# Altium schematic sheet MIXR Power - Battery Management System.SchDoc
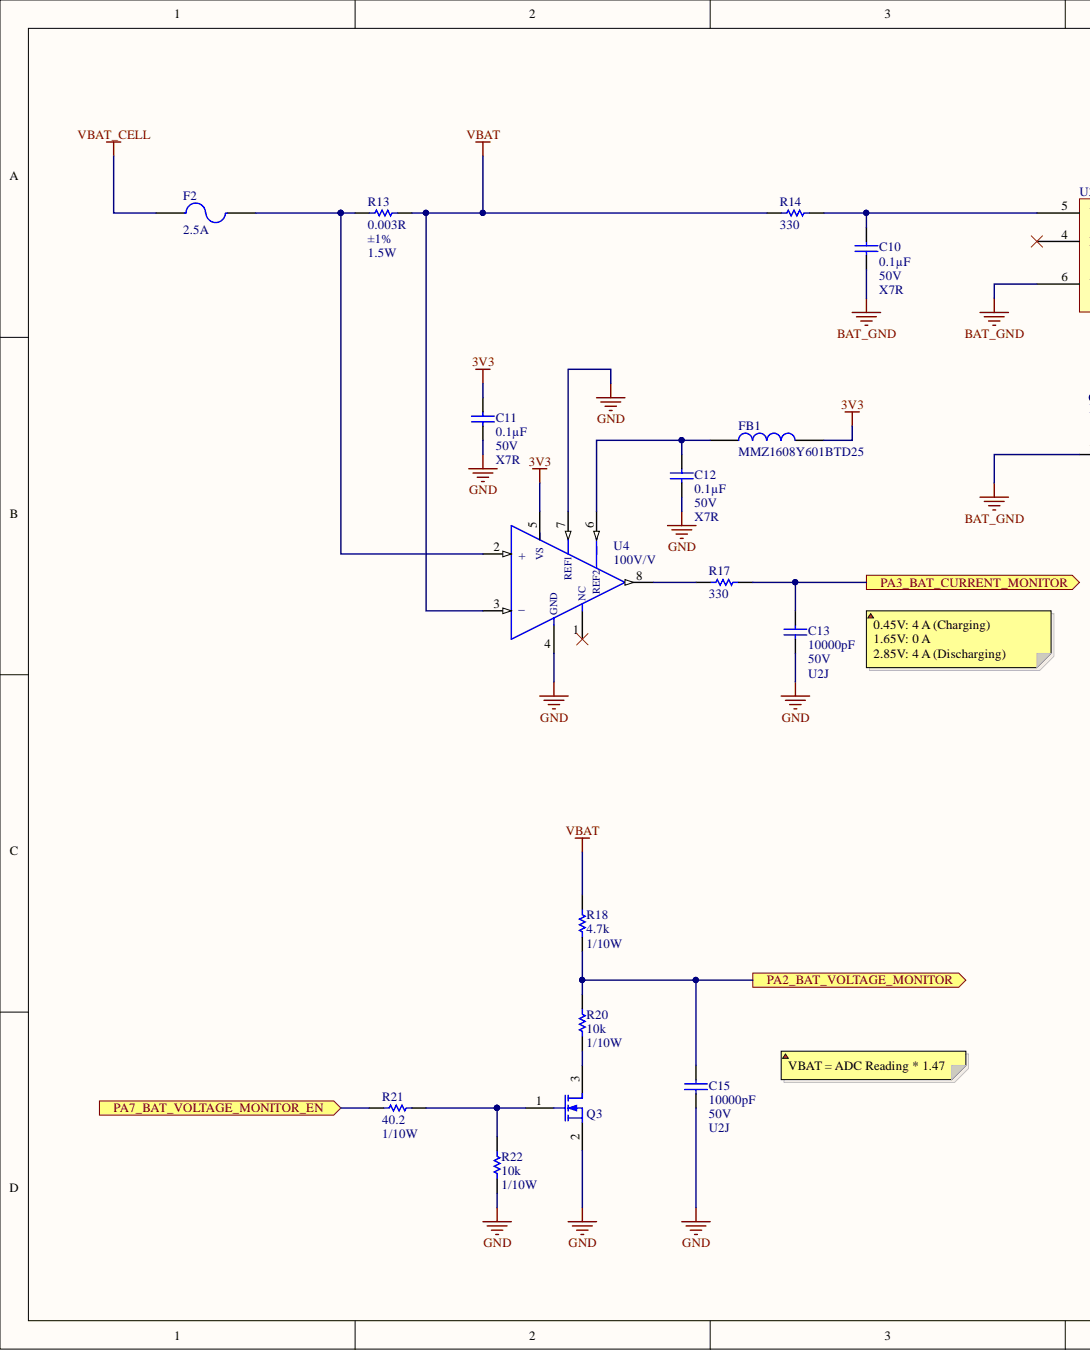
[diagram p0: MIXR Power - Battery Management System.SchDoc, left]
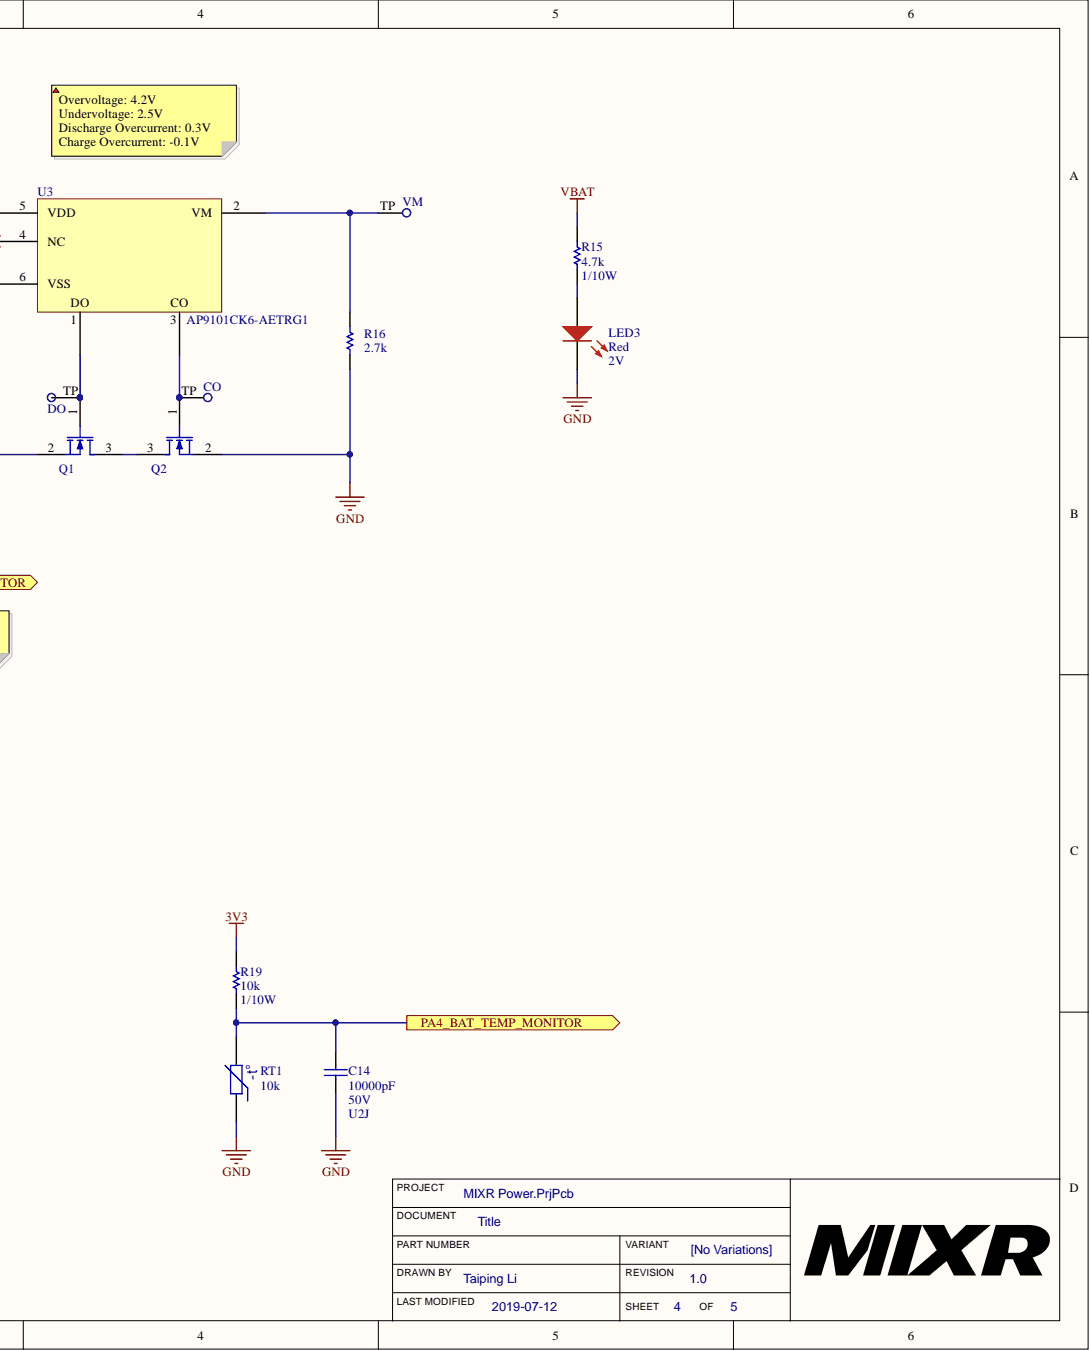
[diagram p1: MIXR Power - Battery Management System.SchDoc, right]

## Parts
C10  Capacitance: 0.1µF  Temperature Coefficient: X7R  Voltage: 50V  [CAP, 0603]  (hidden comment: CAP CER 0.1UF 50V 10% X7R 0603)
C11  Capacitance: 0.1µF  Temperature Coefficient: X7R  Voltage: 50V  [CAP, 0603]  (hidden comment: CAP CER 0.1UF 50V 10% X7R 0603)
C12  Capacitance: 0.1µF  Temperature Coefficient: X7R  Voltage: 50V  [CAP, 0603]  (hidden comment: CAP CER 0.1UF 50V 10% X7R 0603)
C13  Capacitance: 10000pF  Temperature Coefficient: U2J  Voltage: 50V  [CAP, 0603]  (hidden comment: CAP CER 10nF 50V 5% X7R 0603)
C14  Capacitance: 10000pF  Temperature Coefficient: U2J  Voltage: 50V  [CAP, 0603]  (hidden comment: CAP CER 10nF 50V 5% X7R 0603)
C15  Capacitance: 10000pF  Temperature Coefficient: U2J  Voltage: 50V  [CAP, 0603]  (hidden comment: CAP CER 10nF 50V 5% X7R 0603)
CO  Test Point  [Test Point]  (value not drawn)  (no BOM)
DO  Test Point  [Test Point]  (value not drawn)  (no BOM)
F2  Current Rating: 2.5A  [FUSE LITTLEFUSE 0466 1206]  (hidden comment: FUSE 2.5A 32VDC 1206)
FB1  Manufacturer Part Number: MMZ1608Y601BTD25  [FB 0603]  (hidden comment: FB 600 OHM 1LN 0603)
LED3  Color: Red  Voltage - Forward (Vf) (Typ): 2V  [LED_0603]  (hidden comment: LED RED CLEAR 2V 0603)
Q1  MOSFET N-CH 30V 6.2A 0.9W SOT-23  [MOSFET N-CH 30V 6.2A 0.9W SOT-23]  (value not drawn)
Q2  MOSFET N-CH 30V 6.2A 0.9W SOT-23  [MOSFET N-CH 30V 6.2A 0.9W SOT-23]  (value not drawn)
Q3  MOSFET N-CH 30V 6.2A 0.9W SOT-23  [MOSFET N-CH 30V 6.2A 0.9W SOT-23]  (value not drawn)
R13  Power (Watts): 1.5W  Resistance (Ohms): 0.003R  Tolerance: ±1%  [RES 2010]  (hidden comment: RES 0.003 OHM 1% 1.5W 2010)
R14  value 330  [RES, 0603]  (hidden comment: RES 330 OHM 1% 1/10W 0603)
R15  Power (Watts): 1/10W  Resistance (Ohms): 4.7k  [RES, 0603]  (hidden comment: RES 4.7K OHM 1% 1/10W 0603)
R16  2.7k  [RES, 0603]
R17  value 330  [RES, 0603]  (hidden comment: RES 330 OHM 1% 1/10W 0603)
R18  Power (Watts): 1/10W  Resistance (Ohms): 4.7k  [RES, 0603]  (hidden comment: RES 4.7K OHM 1% 1/10W 0603)
R19  Power: 1/10W  Resistance: 10k  [RES, 0603]  (hidden comment: RES 10K OHM 1% 1/10W 0603)
R20  Power: 1/10W  Resistance: 10k  [RES, 0603]  (hidden comment: RES 10K OHM 1% 1/10W 0603)
R21  Power: 1/10W  Resistance: 40.2  [RES, 0603]  (hidden comment: RES 40.2 OHM 0.5% 1/10W 0603)
R22  Power: 1/10W  Resistance: 10k  [RES, 0603]  (hidden comment: RES 10K OHM 1% 1/10W 0603)
RT1  Resistance in Ohms @ 25°C: 10k  [RES, 0603]  (hidden comment: NTC THERMISTORS 10K 0603 SMD)
U3  Manufacturer Part Number: AP9101CK6-AETRG1  [SOT23-6]  (hidden comment: IC BATT PROT LI-ION 1-CELL SOT26)
U4  100V/V  [IC CURRENT AMPLIFIER INA240 TSSOP-8]
VM  Test Point  [Test Point]  (value not drawn)  (no BOM)

## Ports
PA2_BAT_VOLTAGE_MONITOR, PA3_BAT_CURRENT_MONITOR, PA4_BAT_TEMP_MONITOR, PA7_BAT_VOLTAGE_MONITOR_EN

## Nets
3V3: C11.1 FB1.2 R19.1 U4.5(VS)
BAT_GND: C10.2 Q1.2 U3.6(VSS)
GND: C11.2 C12.2 C13.2 C14.2 C15.2 LED3.2 Q2.2 Q3.2 R16.2 R22.2 RT1.1 U4.4(GND) U4.7(REF1)
VBAT: R13.2 R14.1 R15.1 R18.1 U4.3(_)
VBAT_CELL: F2.1
PA2_BAT_VOLTAGE_MONITOR: C15.1 R18.2 R20.1 ; port PA2_BAT_VOLTAGE_MONITOR
PA3_BAT_CURRENT_MONITOR: C13.1 R17.2 ; port PA3_BAT_CURRENT_MONITOR
PA4_BAT_TEMP_MONITOR: C14.1 R19.2 RT1.2 ; port PA4_BAT_TEMP_MONITOR
PA7_BAT_VOLTAGE_MONITOR_EN: R21.1 ; port PA7_BAT_VOLTAGE_MONITOR_EN
NetC10_1: C10.1 R14.2 U3.5(VDD)
NetC12_1: C12.1 FB1.1 U4.6
NetCO_TP: CO.TP Q2.1 U3.3(CO)
NetDO_TP: DO.TP Q1.1 U3.1(DO)
NetF2_2: F2.2 R13.1 U4.2(+)
NetLED3_1: LED3.1 R15.2
NetQ1_3: Q1.3 Q2.3
NetQ3_1: Q3.1 R21.2 R22.1
NetQ3_3: Q3.3 R20.2
NetR16_1: R16.1 U3.2(VM) VM.TP
NetR17_1: R17.1 U4.8

Not connected: U3 4(NC); U4 1(NC)

## Library data of the parts
C10-C12 = CAP CER 0.1UF 50V 10% X7R 0603: 0.10µF ±10% 50V Ceramic Capacitor X7R 0603 (1608 Metric); Manufacturer 1: AVX Corporation; Manufacturer Part Number 1: 06035C104KAT2A; Component Kind: Standard; ComponentLink1Description: http://datasheets.avx.com/X7RDielectric.pdf; ComponentLink1URL: http://datasheets.avx.com/X7RDielectric.pdf; Height - Seated (Max): -; Lead Spacing: -; Lead Style: -; Mounting Type: Surface Mount, MLCC; Operating Temperature: -55°C ~ 125°C; Package / Case: 0603 (1608 Metric); Packaging: Cut Tape (CT); Part Status: Active; Ratings: -; Size / Dimension: 0.063" L x 0.032" W (1.60mm x 0.81mm); Supplier 1: Digi-Key; Supplier Part Number 1: 478-5052-1-ND; Thickness (Max): 0.035" (0.90mm); Tolerance: ±10%; Library Name: Schematic Diagrams.SchLib
C13-C15 = CAP CER 10nF 50V 5% X7R 0603: 10000pF ±5% 50V Ceramic Capacitor U2J 0603 (1608 Metric); Manufacturer 1: KEMET; Manufacturer Part Number 1: C0603C103J5JAC7867; Component Kind: Standard; ComponentLink1Description: http://www.kemet.com/docfinder?Partnumber=C0603C103J5JAC7867; ComponentLink1URL: http://www.kemet.com/docfinder?Partnumber=C0603C103J5JAC7867; Failure Rate: -; Height - Seated (Max): -; Lead Spacing: -; Lead Style: -; Mounting Type: Surface Mount, MLCC; Operating Temperature: -55°C ~ 125°C; Package / Case: 0603 (1608 Metric); Packaging: Cut Tape (CT); Part Status: Active; Ratings: -; Size / Dimension: 0.063" L x 0.032" W (1.60mm x 0.80mm); Supplier 1: Digi-Key; Supplier Part Number 1: 399-13384-1-ND; Thickness (Max): 0.034" (0.87mm); Tolerance: ±5%; Library Name: Schematic Diagrams.SchLib
F2 = FUSE 2.5A 32VDC 1206: 2.5A 32V AC 32V DC Fuse Board Mount (Cartridge Style Excluded) Surface Mount 1206 (3216 Metric); Manufacturer 1: Littelfuse; Manufacturer Part Number 1: 046602.5NRHF; Approvals: UL; DC Cold Resistance: 24mR; Power Dissipation: 192.5mW; Case/Package: 1206; Case Code (Imperial): 1206; Case Code (Metric): 3216; Component Kind: Standard; ComponentLink1Description: https://datasheet.ciiva.com/303/littelfuse-fuse-466-303217.pdf?src-supplier=Digi-Key; ComponentLink1URL: https://datasheet.ciiva.com/303/littelfuse-fuse-466-303217.pdf?src-supplier=Digi-Key; ComponentLink2Description: https://datasheet.ciiva.com/18652/littelfuse-fuse-466-datasheet-pdf-18652469.pdf?src-supplier=Digi-Key; ComponentLink2URL: https://datasheet.ciiva.com/18652/littelfuse-fuse-466-datasheet-pdf-18652469.pdf?src-supplier=Digi-Key; ComponentLink3Description: https://datasheet.ciiva.com/16327/littelfuse-fuse-466-16327471.pdf?src-supplier=Future+Electronics; ComponentLink3URL: https://datasheet.ciiva.com/16327/littelfuse-fuse-466-16327471.pdf?src-supplier=Future+Electronics; Depth: 1.52mm; Fuse Type: Board Mount (Cartridge Style Excluded); Height: 0.023inch; Housing Material: Ceramic; Lead Free: Lead Free; Length: 3.18mm; Max Operating Temperature: 90°C; Min Operating Temperature: -55°C; Mount: Surface Mount; Package Quantity: 5000; Packaging: Tape and Reel; Pricing 1: 1=0.84000, 5=0.81200, 10=0.78700, 50=0.66180, 100=0.54930, 250=0.49940, 500=0.42450, 1000=0.37455 (USD); RoHS: Yes; Stock 1: 4995; Supplier 1: Digi-Key; Supplier Part Number 1: F6143CT-ND; Voltage Rating (AC): 32V; Voltage Rating (DC): 32V; Width: 0.06inch; Working Voltage: 32V; Library Name: Schematic Diagrams.SchLib
FB1 = FB 600 OHM 1LN 0603: FERRITE BEAD 600 OHM 0603 1LN; Manufacturer: TDK; DC Resistance (DCR): 400mR; Impedance: 600R; Max Current Rating: 500mA; Number of Lines: 1; Test Frequency: 100MHz; Tolerance: 25%; Case/Package: 0603; Case Code (Imperial): 0603; Case Code (Metric): 1608; Component Kind: Standard; Height: 0.037inch; Length: 0.063inch; Max DC Current: 500mA; Max Operating Temperature: 125°C; Min Operating Temperature: -55°C; Mount: Surface Mount; Package Quantity: 4000; Packaging: Tape and Reel; Pricing 1: 1 = 0.10000, 10 = 0.07600, 25 = 0.06840, 50 = 0.05000, 100 = 0.04330, 250 = 0.03416, 500 = 0.02884, 1000 = 0.02429 (USD); Ratings: AEC-Q200; RoHS: Yes; Stock 1: 19540; Supplier 1: Digi-Key; Supplier Part Number 1: 445-172850-1-ND; Termination: SMD/SMT; Weight: 7.1E-05oz; Width: 0.031inch; Library Name: Schematic Diagrams.SchLib
LED3 = LED RED CLEAR 2V 0603: Red 631nm LED Indication - Discrete 2V 0603 (1608 Metric); Manufacturer: Wurth Electronics Inc.; Manufacturer Part Number: 150060RS75000; Current - Test: 20mA; Lens Color: Colorless; Lens Style/Size: Rectangle with Flat Top, 1.10mm x 0.70mm; Millicandela Rating: 250mcd; Viewing Angle: 140°; Wavelength - Dominant: 625nm; Wavelength - Peak: 630nm; Category: Optoelectronics; Component Kind: Standard; ComponentLink1Description: http://katalog.we-online.de/led/datasheet/150060RS75000.pdf; ComponentLink1URL: http://katalog.we-online.de/led/datasheet/150060RS75000.pdf; Features: -; Height (Max): 0.80mm; Lens Transparency: Clear; Mounting Type: Surface Mount; Package / Case: 0603 (1608 Metric); Packaging: Cut Tape (CT); Part Status: Active; Pricing 1: 1=0.21, 100=0.1617, 500=0.13964 (CAD); RoHS: RoHS Compliant; Size / Dimension: 1.60mm L x 0.80mm W; Stock 1: 35521; Supplier 1: Digi-Key; Supplier Device Package: 0603; Supplier Part Number 1: 732-4978-1-ND; Library Name: Schematic Diagrams.SchLib
Q1-Q3 = MOSFET N-CH 30V 6.2A 0.9W SOT-23: N-Channel 30V 6.2A (Ta) 900mW (Ta) Surface Mount SOT-23; Manufacturer: Diodes; Manufacturer Part Number: DMN3023L-7; Input Capacitance: 873pF; Rds On Max: 25mR; Case/Package: TO-236-3; Component Kind: Standard; ComponentLink1Description: https://www.diodes.com/assets/Datasheets/DMN3023L.pdf; ComponentLink1URL: https://www.diodes.com/assets/Datasheets/DMN3023L.pdf; ComponentLink2Description: http://www.mouser.com/ds/2/115/DMN3023L-959533.pdf; ComponentLink2URL: http://www.mouser.com/ds/2/115/DMN3023L-959533.pdf; Continuous Drain Current (ID): 6.2A; Drain to Source Voltage (Vdss): 30V; Max Operating Temperature: 150°C; Max Power Dissipation: 900mW; Min Operating Temperature: -55°C; Mount: Surface Mount; Packaging: Tape and Reel; Pricing 1: 1=0.47, 10=0.368, 100=0.2529, 500=0.1734, 1000=0.1301 (USD); Stock 1: 38246; Supplier 1: Digi-Key; Supplier Part Number 1: DMN3023L-7DICT-ND; Library Name: Schematic Diagrams.SchLib
R13 = RES 0.003 OHM 1% 1.5W 2010: 0.003 Ohm ±1% 1.5W Chip Resistor 2010 (5025 Metric) Current Sense, Moisture Resistant Metal Foil; Manufacturer 1: Stackpole Electronics Inc.; Manufacturer Part Number 1: CSNL2010FT3L00; Description 1: RES SMD 0.003 OHM 1% 1.5W 2010; Temperature Coefficient: ±50ppm/°C; Category: Resistors; Component Kind: Standard; ComponentLink1Description: https://www.seielect.com/Catalog/SEI-csnl.pdf; ComponentLink1URL: https://www.seielect.com/Catalog/SEI-csnl.pdf; Composition: Metal Foil; Failure Rate: -; Features: Current Sense, Moisture Resistant; Height - Seated (Max): 0.041" (1.04mm); Operating Temperature: -55°C ~ 170°C; Package / Case: 2010 (5025 Metric); Packaging: Cut Tape (CT); Part Status: Active; Pricing 1: 1=1.02, 10=0.844, 25=0.7232, 50=0.6508, 100=0.5665, 250=0.5062, 500=0.4098, 1000=0.33145 (CAD); RoHS: RoHS Compliant; Size / Dimension: 0.200" L x 0.100" W (5.08mm x 2.54mm); Stock 1: 18532; Supplier 1: Digi-Key; Supplier Device Package: 2010; Supplier Part Number 1: CSNL2010FT3L00CT-ND; Library Name: Schematic Diagrams.SchLib
R14 R17 = RES 330 OHM 1% 1/10W 0603: 330 Ohms ±1% 0.1W, 1/10W Chip Resistor 0603 (1608 Metric) Automotive AEC-Q200, Moisture Resistant Thick Film; Manufacturer: TE Connectivity; Manufacturer Part Number: CRGCQ0603F330R; Component Kind: Standard; ComponentLink1Description: http://www.te.com/commerce/DocumentDelivery/DDEController?Action=srchrtrv&DocNm=1773204-3&DocType=DS&DocLang=English&src-supplier=Digi-Key; ComponentLink1URL: http://www.te.com/commerce/DocumentDelivery/DDEController?Action=srchrtrv&DocNm=1773204-3&DocType=DS&DocLang=English&src-supplier=Digi-Key; ComponentLink2Description: https://www.te.com/commerce/DocumentDelivery/DDEController?Action=srchrtrv&DocNm=1773204-3&DocType=DS&DocLang=English&src-supplier=Digi-Key; ComponentLink2URL: https://www.te.com/commerce/DocumentDelivery/DDEController?Action=srchrtrv&DocNm=1773204-3&DocType=DS&DocLang=English&src-supplier=Digi-Key; Pricing 1: 1 = 0.10000, 10 = 0.03300, 100 = 0.01320, 1000 = 0.00595, 2500 = 0.00516 (USD); RoHS: No; Stock 1: 87700; Supplier 1: Digi-Key; Supplier Part Number 1: A129682CT-ND; Library Name: Schematic Diagrams.SchLib
R15 R18 = RES 4.7K OHM 1% 1/10W 0603: 4.7k Ohm ±1% 0.1W, 1/10W Chip Resistor 0603 (1608 Metric) Moisture Resistant Thick Film; Manufacturer 1: Yageo; Manufacturer Part Number 1: RC0603FR-074K7L; Description 1: RES SMD 4.7K OHM 1% 1/10W 0603; Temperature Coefficient: ±100ppm/°C; Category: Resistors; Component Kind: Standard; ComponentLink1Description: http://www.yageo.com/documents/recent/PYu-RC_Group_51_RoHS_L_7.pdf; ComponentLink1URL: http://www.yageo.com/documents/recent/PYu-RC_Group_51_RoHS_L_7.pdf; Composition: Thick Film; Failure Rate: -; Features: Moisture Resistant; Height - Seated (Max): 0.022" (0.55mm); Operating Temperature: -55°C ~ 155°C; Package / Case: 0603 (1608 Metric); Packaging: Cut Tape (CT); Part Status: Active; Pricing 1: 1=0.16, 10=0.022, 100=0.0089, 1000=0.00402, 2500=0.00349 (CAD); RoHS: RoHS Compliant; Size / Dimension: 0.063" L x 0.031" W (1.60mm x 0.80mm); Stock 1: 3487566; Supplier 1: Digi-Key; Supplier Device Package: 0603; Supplier Part Number 1: 311-4.70KHRCT-ND; Tolerance: ±1%; Library Name: Schematic Diagrams.SchLib
R16 = RES 2.7K OHM 1% 1/10W 0603: 2.7 kOhms ±1% 0.1W, 1/10W Chip Resistor 0603 (1608 Metric) Automotive AEC-Q200, Moisture Resistant Thick Film; Manufacturer: TE Connectivity; Manufacturer Part Number: CRGCQ0603F2K7; Component Kind: Standard; ComponentLink1Description: http://www.te.com/commerce/DocumentDelivery/DDEController?Action=srchrtrv&DocNm=1773204-3&DocType=DS&DocLang=English&src-supplier=Digi-Key; ComponentLink1URL: http://www.te.com/commerce/DocumentDelivery/DDEController?Action=srchrtrv&DocNm=1773204-3&DocType=DS&DocLang=English&src-supplier=Digi-Key; ComponentLink2Description: https://www.te.com/commerce/DocumentDelivery/DDEController?Action=srchrtrv&DocNm=1773204-3&DocType=DS&DocLang=English&src-supplier=Digi-Key; ComponentLink2URL: https://www.te.com/commerce/DocumentDelivery/DDEController?Action=srchrtrv&DocNm=1773204-3&DocType=DS&DocLang=English&src-supplier=Digi-Key; Pricing 1: 1 = 0.10000, 10 = 0.03300, 100 = 0.01320, 1000 = 0.00595, 2500 = 0.00516 (USD); RoHS: No; Stock 1: 137187; Supplier 1: Digi-Key; Supplier Part Number 1: A129693CT-ND; Library Name: Schematic Diagrams.SchLib
R19 R20 R22 = RES 10K OHM 1% 1/10W 0603: RES SMD 10K OHM 1% 1/10W 0603; Manufacturer 1: Yageo; Manufacturer Part Number 1: RC0603FR-0710KL; Temperature Coefficient: ±100ppm/°C; Component Kind: Standard; ComponentLink1Description: http://www.yageo.com/documents/recent/PYu-RC_Group_51_RoHS_L_7.pdf; ComponentLink1URL: http://www.yageo.com/documents/recent/PYu-RC_Group_51_RoHS_L_7.pdf; Composition: Thick Film; Failure Rate: -; Height - Seated (Max): 0.022" (0.55mm); Operating Temperature: -55°C ~ 155°C; Package / Case: 0603 (1608 Metric); Packaging: Cut Tape (CT); Part Status: Active; Size / Dimension: 0.063" L x 0.031" W (1.60mm x 0.80mm); Supplier 1: Digi-Key; Supplier Device Package: 0603; Supplier Part Number 1: 311-10.0KHRCT-ND; Tolerance: ±1%; Library Name: Schematic Diagrams.SchLib
R21 = RES 40.2 OHM 0.5% 1/10W 0603: RES SMD 40.2 OHM 0.5% 1/10W 0603; Manufacturer 1: Yageo; Manufacturer Part Number 1: RT0603DRE0740R2L; Temperature Coefficient: ±50ppm/°C; Component Kind: Standard; ComponentLink1Description: http://www.yageo.com/documents/recent/PYu-RT_1-to-0.01_RoHS_L_7.pdf; ComponentLink1URL: http://www.yageo.com/documents/recent/PYu-RT_1-to-0.01_RoHS_L_7.pdf; Composition: Thin Film; Failure Rate: -; Height - Seated (Max): 0.022" (0.55mm); Operating Temperature: -55°C ~ 155°C; Package / Case: 0603 (1608 Metric); Packaging: Cut Tape (CT); Part Status: Active; Size / Dimension: 0.063" L x 0.031" W (1.60mm x 0.80mm); Supplier 1: Digi-Key; Supplier Device Package: 0603; Supplier Part Number 1: 311-2576-1-ND; Tolerance: ±0.5%; Library Name: Schematic Diagrams.SchLib
RT1 = NTC THERMISTORS 10K 0603 SMD: NTC THERMISTORS 10KOHM 1608 SMD; Manufacturer: Murata Electronics North America; Manufacturer Part Number: NCU18XH103F60RB; B25/50: 3380K; B25/85: 3434K; B25/100: 3455K; B Value Tolerance: ±1%; B0/50: -; B25/75: -; Category: Sensors, Transducers; Component Kind: Standard; ComponentLink1Description: https://www.murata.com/~/media/webrenewal/support/library/catalog/products/thermistor/r03e.ashx; ComponentLink1URL: https://www.murata.com/~/media/webrenewal/support/library/catalog/products/thermistor/r03e.ashx; Length - Lead Wire: -; Mounting Type: Surface Mount; Operating Temperature: -40°C ~ 125°C; Package / Case: 0603 (1608 Metric); Packaging: Tape & Reel (TR); Part Status: Active; Pricing 1: 4000=0.06771, 8000=0.05974, 12000=0.05775, 20000=0.05675, 28000=0.05576 (CAD); Resistance Tolerance: ±1%; RoHS: Request Inventory Verification; Stock 1: 0; Supplier 1: Digi-Key; Supplier Part Number 1: 490-16279-2-ND; Library Name: Schematic Diagrams.SchLib
U3 = IC BATT PROT LI-ION 1-CELL SOT26: Battery Battery Protection IC Lithium Ion/Polymer SOT-26; Manufacturer: Diodes; Fault Protection: Over Current; Number of Cells: 1; Case/Package: SOT-23-6; Component Kind: Standard; ComponentLink1Description: https://www.diodes.com/assets/Datasheets/AP9101C.pdf?src-supplier=Digi-Key; ComponentLink1URL: https://www.diodes.com/assets/Datasheets/AP9101C.pdf?src-supplier=Digi-Key; Max Operating Temperature: 85°C; Min Operating Temperature: -40°C; Pricing 1: 1 = 0.45000, 10 = 0.36300, 100 = 0.24720, 500 = 0.18540, 1000 = 0.13905 (USD); RoHS: Yes; Stock 1: 5917; Supplier 1: Digi-Key; Supplier Part Number 1: AP9101CK6-AETRG1DICT-ND; Library Name: Schematic Diagrams.SchLib
U4 = IC CURRENT AMPLIFIER INA240 8-TSSOP: Current Sense Amplifier 1 Circuit 8-TSSOP; Manufacturer 1: Texas Instruments; Manufacturer Part Number 1: INA240A3PWR; Current - Supply: 1.8mA; Description 1: IC AMP CURRENT SENSE 8-TSSOP; Slew Rate: 2 V/µs; Voltage - Supply, Single/Dual (±): 2.7 V ~ 5.5 V; Amplifier Type: Current Sense; Category: Integrated Circuits (ICs); Component Kind: Standard; ComponentLink1Description: http://www.ti.com/lit/ds/symlink/ina240.pdf; ComponentLink1URL: http://www.ti.com/lit/ds/symlink/ina240.pdf; Mounting Type: Surface Mount; Operating Temperature: -40°C ~ 125°C; Output Type: -; Package / Case: 8-TSSOP (0.173", 4.40mm Width); Packaging: Cut Tape (CT); Part Status: Active; Pricing 1: 1=3.79, 10=3.397, 100=2.7311, 500=2.24388, 1000=1.85921 (CAD); RoHS: RoHS Compliant; Stock 1: 1286; Supplier 1: Digi-Key; Supplier Device Package: 8-TSSOP; Supplier Part Number 1: 296-45090-1-ND; Library Name: Schematic Diagrams.SchLib
CO DO VM = Test Point: Library Name: Schematic Diagrams.SchLib
